# Altium schematic sheet Sheet1.SchDoc(Sheet1)
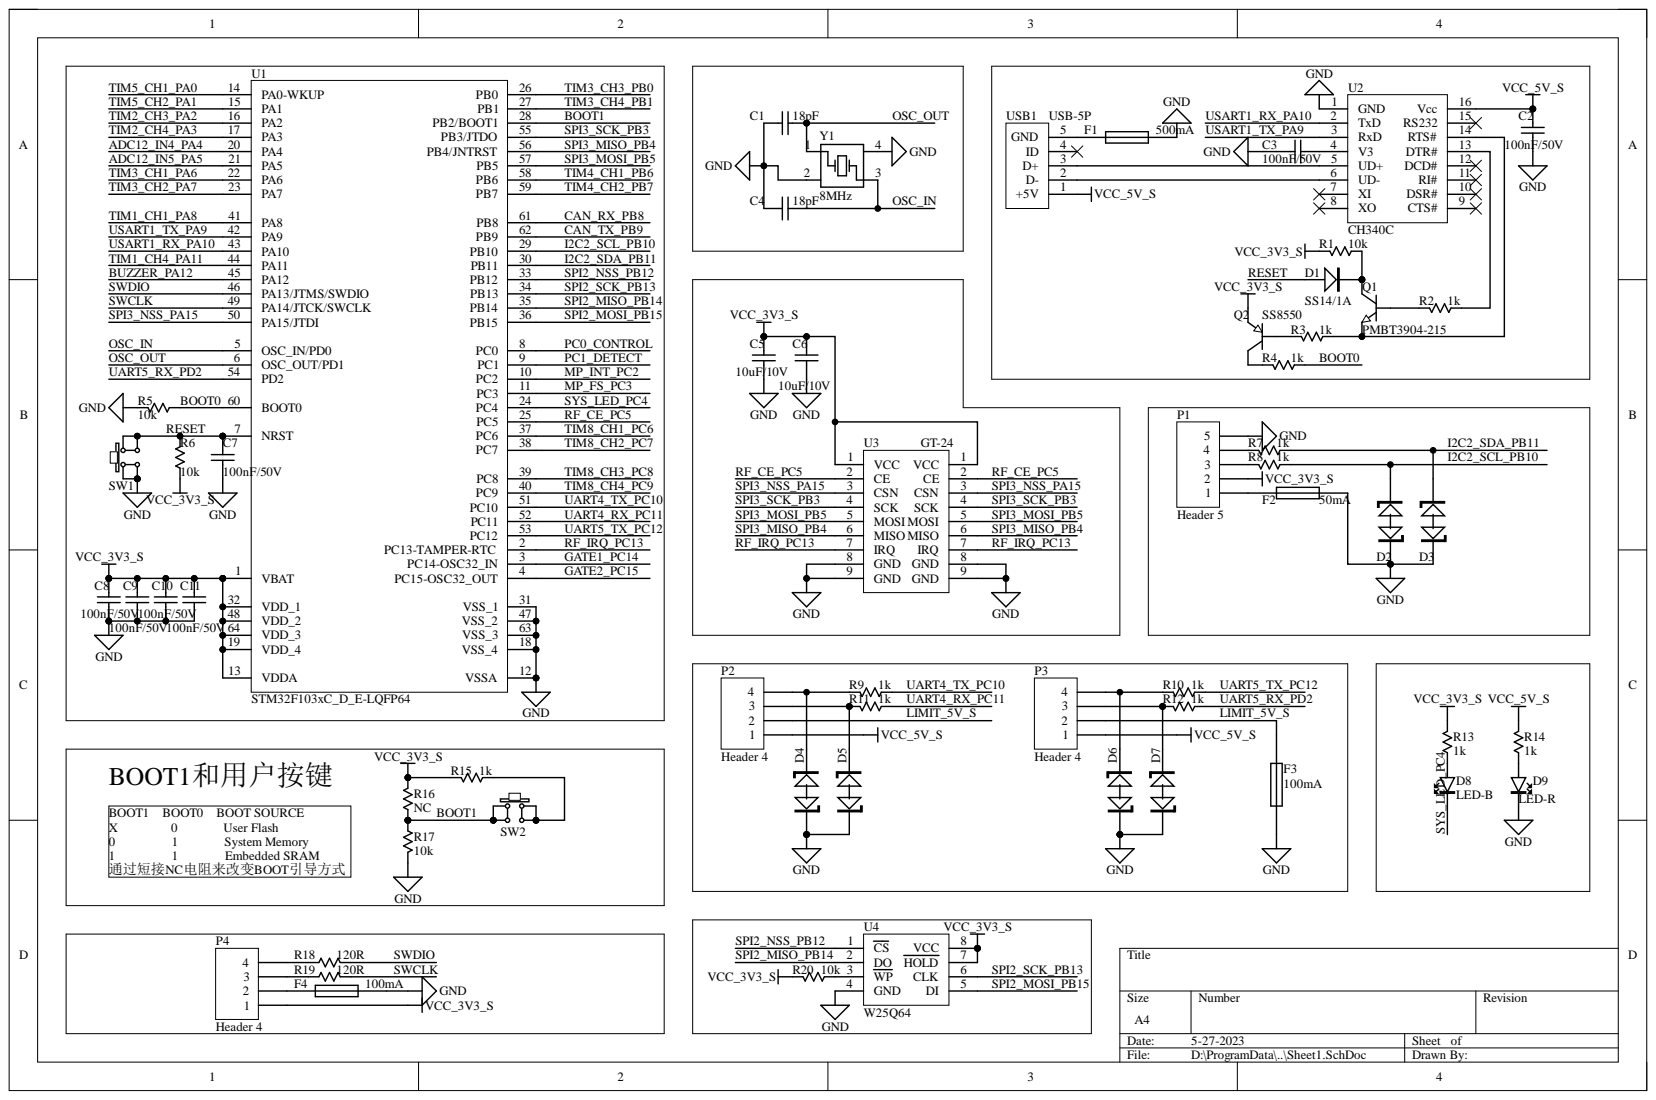
[diagram p0: Sheet1.SchDoc]

## Parts
C1  18pF  [C 0402]
C2  100nF/50V  [C 0402]
C3  100nF/50V  [C 0402]
C4  18pF  [C 0402]
C5  10uF/10V  [C 0402]
C6  10uF/10V  [C 0402]
C7  100nF/50V  [C 0402]
C8  100nF/50V  [C 0402]
C9  100nF/50V  [C 0402]
C10  100nF/50V  [C 0402]
C11  100nF/50V  [C 0402]
D1  SS14/1A  [SOD-323]
D2  PESD5V0V1BL  [SOD882]  (value not drawn)
D3  PESD5V0V1BL  [SOD882]  (value not drawn)
D4  PESD5V0V1BL  [SOD882]  (value not drawn)
D5  PESD5V0V1BL  [SOD882]  (value not drawn)
D6  PESD5V0V1BL  [SOD882]  (value not drawn)
D7  PESD5V0V1BL  [SOD882]  (value not drawn)
D8  LED-B  [LED 0603B]
D9  LED-R  [LED 0603R]
F1  500mA  [R 0603]
F2  50mA  [R 0603]
F3  100mA  [R 0603]
F4  100mA  [R 0603]
P1  Header 5  [OLED0.96-12864_4pin]
P2  Header 4  [HDR-1X4_M]
P3  Header 4  [HDR-1X4_M]
P4  Header 4  [HDR-1X4_M]
Q1  PMBT3904-215  [SOT23-3N]
Q2  SS8550  [SOT23-3N]
R1  10k  [R 0402]
R2  1k  [R 0402]
R3  1k  [R 0402]
R4  1k  [R 0402]
R5  10k  [R 0402]
R6  10k  [R 0402]
R7  1k  [R 0402]
R8  1k  [R 0402]
R9  1k  [R 0402]
R10  1k  [R 0402]
R11  1k  [R 0402]
R12  1k  [R 0402]
R13  1k  [R 0402]
R14  1k  [R 0402]
R15  1k  [R 0402]
R16  NC  [R 0402]
R17  10k  [R 0402]
R18  120R  [R 0402]
R19  120R  [R 0402]
R20  10k  [R 0402]
SW1  6x6x5  [TS-A016]  (value not drawn)
SW2  6x6x5  [TS-A016]  (value not drawn)
U1  STM32F103xC_D_E-LQFP64  [LQFP64 10x10_N]
U2  CH340C  [SOP16_L]
U3  GT-24  [GT-24]
U4  W25Q64  [SOP8W_N]
USB1  USB-5P  [USB-micro_B]
Y1  8MHz  [XTAL-3225]

## Nets
GND: C1.2 C2.1 C3.2 C4.2 C5.1 C6.1 C7.1 C8.1 C9.1 C10.1 C11.1 D2.2 D3.2 D4.2 D5.2 D6.2 D7.2 D9.2 F1.1 F2.2 F3.2 F4.1 P1.5 R5.1 R17.1 SW1.1 SW1.4 U1.12(VSSA) U1.18(VSS_4) U1.31(VSS_1) U1.47(VSS_2) U1.63(VSS_3) U2.1(GND) U3.8(GND) U3.9(GND) U4.4(GND) Y1.2 Y1.4
VCC_3V3_S: C5.2 C6.2 C8.2 C9.2 C10.2 C11.2 P1.2 P4.1 Q2.2 R1.1 R6.2 R13.1 R15.1 R16.1 R20.1 U1.1(VBAT) U1.13(VDDA) U1.19(VDD_4) U1.32(VDD_1) U1.48(VDD_2) U1.64(VDD_3) U3.1(VCC) U4.7(HOLD) U4.8(VCC)
VCC_5V_S: C2.2 P2.1 P3.1 R14.1 U2.16(Vcc) USB1.1(+5V)
ADC12_IN4_PA4: U1.20(PA4)
ADC12_IN5_PA5: U1.21(PA5)
BOOT0: R4.2 R5.2 U1.60(BOOT0)
BOOT1: R16.2 R17.2 SW2.1 SW2.4 U1.28(PB2/BOOT1)
BUZZER_PA12: U1.45(PA12)
CAN_RX_PB8: U1.61(PB8)
CAN_TX_PB9: U1.62(PB9)
GATE1_PC14: U1.3(PC14-OSC32_IN)
GATE2_PC15: U1.4(PC15-OSC32_OUT)
I2C2_SCL_PB10: D2.1 R8.2 U1.29(PB10)
I2C2_SDA_PB11: D3.1 R7.2 U1.30(PB11)
LIMIT_5V_S: F3.1 P2.2 P3.2
MP_FS_PC3: U1.11(PC3)
MP_INT_PC2: U1.10(PC2)
OSC_IN: C4.1 U1.5(OSC_IN/PD0) Y1.3
OSC_OUT: C1.1 U1.6(OSC_OUT/PD1) Y1.1
PC0_CONTROL: U1.8(PC0)
PC1_DETECT: U1.9(PC1)
RESET: C7.2 D1.1 R6.1 SW1.2 SW1.3 U1.7(NRST)
RF_CE_PC5: U1.25(PC5) U3.2(CE)
RF_IRQ_PC13: U1.2(PC13-TAMPER-RTC) U3.7(IRQ)
SPI2_MISO_PB14: U1.35(PB14) U4.2(DO)
SPI2_MOSI_PB15: U1.36(PB15) U4.5(DI)
SPI2_NSS_PB12: U1.33(PB12) U4.1(CS)
SPI2_SCK_PB13: U1.34(PB13) U4.6(CLK)
SPI3_MISO_PB4: U1.56(PB4/JNTRST) U3.6(MISO_MISO)
SPI3_MOSI_PB5: U1.57(PB5) U3.5(MOSI_MOSI)
SPI3_NSS_PA15: U1.50(PA15/JTDI) U3.3(CSN)
SPI3_SCK_PB3: U1.55(PB3/JTDO) U3.4(SCK)
SWCLK: R19.1 U1.49(PA14/JTCK/SWCLK)
SWDIO: R18.1 U1.46(PA13/JTMS/SWDIO)
SYS_LED_PC4: D8.2 U1.24(PC4)
TIM1_CH1_PA8: U1.41(PA8)
TIM1_CH4_PA11: U1.44(PA11)
TIM2_CH3_PA2: U1.16(PA2)
TIM2_CH4_PA3: U1.17(PA3)
TIM3_CH1_PA6: U1.22(PA6)
TIM3_CH2_PA7: U1.23(PA7)
TIM3_CH3_PB0: U1.26(PB0)
TIM3_CH4_PB1: U1.27(PB1)
TIM4_CH1_PB6: U1.58(PB6)
TIM4_CH2_PB7: U1.59(PB7)
TIM5_CH1_PA0: U1.14(PA0-WKUP)
TIM5_CH2_PA1: U1.15(PA1)
TIM8_CH1_PC6: U1.37(PC6)
TIM8_CH2_PC7: U1.38(PC7)
TIM8_CH3_PC8: U1.39(PC8)
TIM8_CH4_PC9: U1.40(PC9)
UART4_RX_PC11: R11.2 U1.52(PC11)
UART4_TX_PC10: R9.2 U1.51(PC10)
UART5_RX_PD2: R12.2 U1.54(PD2)
UART5_TX_PC12: R10.2 U1.53(PC12)
USART1_RX_PA10: U1.43(PA10) U2.2(TxD)
USART1_TX_PA9: U1.42(PA9) U2.3(RxD)
NetC3_1: C3.1 U2.4(V3)
NetD1_2: D1.2 Q1.3 R1.2
NetD4_1: D4.1 P2.4 R9.1
NetD5_1: D5.1 P2.3 R11.1
NetD6_1: D6.1 P3.4 R10.1
NetD7_1: D7.1 P3.3 R12.1
NetD8_1: D8.1 R13.2
NetD9_1: D9.1 R14.2
NetF1_2: F1.2 USB1.5(GND)
NetF2_1: F2.1 P1.1
NetF4_2: F4.2 P4.2
NetP1_3: P1.3 R8.1
NetP1_4: P1.4 R7.1
NetP4_3: P4.3 R19.2
NetP4_4: P4.4 R18.2
NetQ1_1: Q1.1 R2.1
NetQ1_2: Q1.2 R3.2 U2.14(RTS#)
NetQ2_1: Q2.1 R3.1
NetQ2_3: Q2.3 R4.1
NetR2_2: R2.2 U2.13(DTR#)
NetR15_2: R15.2 SW2.2 SW2.3
NetR20_2: R20.2 U4.3(WP)
NetU2_5: U2.5(UD+) USB1.3(D+)
NetU2_6: U2.6(UD-) USB1.2(D-)

Not connected: U2 7(XI) 8(XO) 9(CTS#) 10(DSR#) 11(RI#) 12(DCD#) 15(RS232); USB1 4(ID)

## Library data of the parts
C1-C11 = CAP: Capacitor; Component Kind: Standard; Library Name: Passive Components Class.SchLib
D1 = 1N4007: 整流二极管; 版权归属: 黎明电子style; BOM名称: 整流二极管; Component Kind: Standard; Library Name: 二极管-整流桥.SchLib; 学习资源: https://lmdzstyle.taobao.com/
D8 D9 = LED: Light Emitting Diode; Component Kind: Standard; Library Name: Passive Components Class.SchLib
F1-F4 = Fuse 1: Fuse; Component Kind: Standard; LatestRevisionDate: 17-Jul-2002; LatestRevisionNote: Re-released for DXP Platform.; Library Name: Miscellaneous Devices.IntLib; PackageDescription: Thru-Hole; 2 Leads; PackageReference: PIN-W2/E2.8; Published: 8-Jun-2000; Simulation: FUSE; Publisher: Altium Limited
P1 = Header 5: Header, 5-Pin; Component Kind: Standard; LatestRevisionDate: 17-Jul-2002; LatestRevisionNote: Re-released for DXP Platform.; Library Name: Miscellaneous Connectors.IntLib; Signal Integrity: Connector; Publisher: Altium Limited
P2-P4 = Header 4: Header, 4-Pin; Component Kind: Standard; LatestRevisionDate: 17-Jul-2002; LatestRevisionNote: Re-released for DXP Platform.; Library Name: Miscellaneous Connectors.IntLib; Signal Integrity: Connector; Publisher: Altium Limited
Q1 = 8050-SMD: 高频放大-NPN型; 属性: 40V 1.5A 1W 100MHZ; 版权归属: 黎明电子style; BOM名称: 高频放大-NPN型; Component Kind: Standard; Library Name: 三极管.SchLib; 学习资源: https://lmdzstyle.taobao.com/
Q2 = 8550-SMD: 高频放大-PNP型; 属性: 40V 1.5A 1W 100MHZ; 版权归属: 黎明电子style; BOM名称: 高频放大-PNP型; Component Kind: Standard; Library Name: 三极管.SchLib; 学习资源: https://lmdzstyle.taobao.com/
R1-R20 = RES: Resistor; Component Kind: Standard; Library Name: Passive Components Class.SchLib
SW1 SW2 = TSW SMD-6x6: 贴片6x6轻触开关; 版权归属: 黎明电子style; BOM名称: 贴片6x6轻触开关; Component Kind: Standard; Library Name: 按键-开关.SchLib; 学习资源: https://lmdzstyle.taobao.com/
U1 = STM32F103xC_D_E-LQFP64: High-density performance line ARM-based 32-bit MCU with 256 to 512KB Flash, USB, CAN, 11 timers, 3 ADCs, 13 communication interfaces; Component Kind: Standard; Library Name: Microcontrollers Class.SchLib
U2 = CH340G: 串口转USB; 版权归属: 黎明电子style; BOM名称: 串口转USB; Component Kind: Standard; Library Name: IC芯片.SchLib; 学习资源: https://lmdzstyle.taobao.com/
U4 = W25Qxx: 外置Flash; 版权归属: 黎明电子style; BOM名称: 外置Flash; Component Kind: Standard; Library Name: IC芯片.SchLib; 学习资源: https://lmdzstyle.taobao.com/
USB1 = USB-5P: 微型USB母座; 版权归属: 黎明电子style; BOM名称: 微型USB母座; Component Kind: Standard; Library Name: 接口类.SchLib; 学习资源: https://lmdzstyle.taobao.com/
Y1 = crystal: Component Kind: Standard; Description: crystal; Library Name: IC芯片.SchLib
D2-D7 = PESD5V0V1BL: Component Kind: Standard; Description: PESD5V0V1BL; Library Name: 二极管-整流桥.SchLib
U3 = GT-24: Component Kind: Standard; Description: GT-24; Library Name: 模块.SchLib
